# Altium schematic sheet SectionE_BreakOut.SchDoc(SectionE_BreakOut)
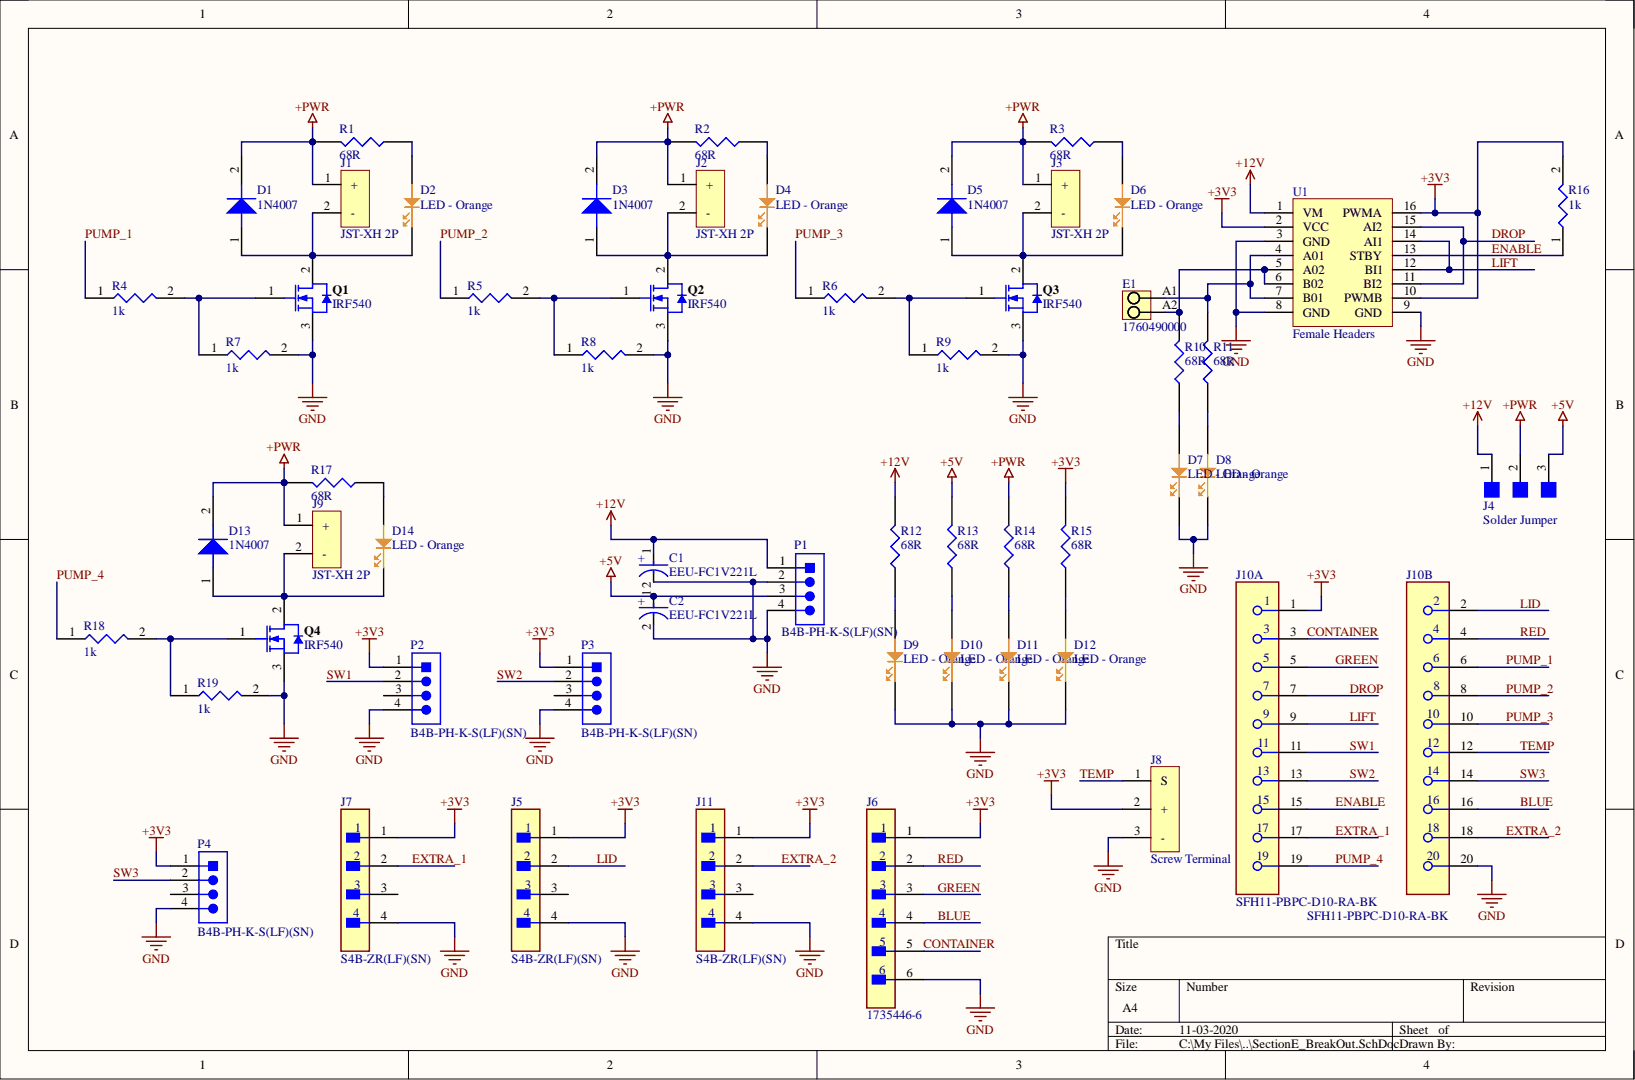
[diagram p0: SectionE_BreakOut.SchDoc]

## Parts
C1  EEU-FC1V221L  [FP-EEU-FC1V221L-MFG]
C2  EEU-FC1V221L  [FP-EEU-FC1V221L-MFG]
D1  1N4007  [M7 1N4007 SMA]
D2  LED - Orange  [LED0603-Orange]
D3  1N4007  [M7 1N4007 SMA]
D4  LED - Orange  [LED0603-Orange]
D5  1N4007  [M7 1N4007 SMA]
D6  LED - Orange  [LED0603-Orange]
D7  LED - Orange  [LED0603-Orange]
D8  LED - Orange  Color: Orange  [LED0603-Orange]
D9  LED - Orange  [LED0603-Orange]
D10  LED - Orange  Color: Orange  [LED0603-Orange]
D11  LED - Orange  Color: Orange  [LED0603-Orange]
D12  LED - Orange  Color: Orange  [LED0603-Orange]
D13  1N4007  [M7 1N4007 SMA]
D14  LED - Orange  [LED0603-Orange]
E1  1760490000  [PM_5_08_90_02]
J1  JST-XH 2P  [JST-XH 2P]
J2  JST-XH 2P  [JST-XH 2P]
J3  JST-XH 2P  [JST-XH 2P]
J4  Solder Jumper  [Solder Jumper]
J5  S4B-ZR(LF)(SN)  [FP-S4B-ZR_LF_SN-MFG]
J6  1735446-6  [FP-1735446-6-MFG]
J7  S4B-ZR(LF)(SN)  [FP-S4B-ZR_LF_SN-MFG]
J8  Screw Terminal  Termination: Screw  [Screw Terminal 3P 5.08MM]
J9  JST-XH 2P  [JST-XH 2P]
J10  SFH11-PBPC-D10-RA-BK  [FP-SFH11-PBPC-D10-RA-BK-MFG]  (parts A,B)
J11  S4B-ZR(LF)(SN)  [FP-S4B-ZR_LF_SN-MFG]
P1  B4B-PH-K-S(LF)(SN)  [JST-B4B-PH-K-S_V]
P2  B4B-PH-K-S(LF)(SN)  [JST-B4B-PH-K-S_V]
P3  B4B-PH-K-S(LF)(SN)  [JST-B4B-PH-K-S_V]
P4  B4B-PH-K-S(LF)(SN)  [JST-B4B-PH-K-S_V]
Q1  IRF540  [VISH-TO-220AB-3_A]
Q2  IRF540  [VISH-TO-220AB-3_A]
Q3  IRF540  [VISH-TO-220AB-3_A]
Q4  IRF540  [VISH-TO-220AB-3_A]
R1  68R  [68R 0603]
R2  68R  [68R 0603]
R3  68R  [68R 0603]
R4  1k  [R0603 1k]
R5  1k  [R0603 1k]
R6  1k  [R0603 1k]
R7  1k  [R0603 1k]
R8  1k  [R0603 1k]
R9  1k  [R0603 1k]
R10  68R  [68R 0603]
R11  68R  [68R 0603]
R12  68R  [68R 0603]
R13  68R  [68R 0603]
R14  68R  [68R 0603]
R15  68R  [68R 0603]
R16  1k  [R0603 1k]
R17  68R  [68R 0603]
R18  1k  [R0603 1k]
R19  1k  [R0603 1k]
U1  Female Headers  [TB6612FNG]

## Nets
+3V3: J5.1 J6.1 J7.1 J8.2 J10.1 J11.1 P2.1 P3.1 P4.1 R15.2 R16.2 U1.2(VCC) U1.10(PWMB) U1.16(PWMA)
+5V: C2.1 J4.3 P1.3 R13.2
+12V: C1.1 J4.1 P1.1 R12.2 U1.1(VM)
+PWR: D1.2 D3.2 D5.2 D13.2 J1.1 J2.1 J3.1 J4.2 J9.1 R1.2 R2.2 R3.2 R14.2 R17.2
GND: C1.2 C2.2 D7.1 D8.1 D9.1 D10.1 D11.1 D12.1 J5.4 J6.6 J7.4 J8.3 J10.20 J11.4 P1.2 P1.4 P2.4 P3.4 P4.4 Q1.3 Q2.3 Q3.3 Q4.3 R7.2 R8.2 R9.2 R19.2 U1.3(GND) U1.8(GND) U1.9(GND)
BLUE: J6.4 J10.16
CONTAINER: J6.5 J10.3
DROP: J10.7 U1.11(BI2) U1.15(AI2)
ENABLE: J10.15 R16.1 U1.13(STBY)
EXTRA_1: J7.2 J10.17
EXTRA_2: J10.18 J11.2
GREEN: J6.3 J10.5
LID: J5.2 J10.2
LIFT: J10.9 U1.12(BI1) U1.14(AI1)
PUMP_1: J10.6 R4.1
PUMP_2: J10.8 R5.1
PUMP_3: J10.10 R6.1
PUMP_4: J10.19 R18.1
RED: J6.2 J10.4
SW1: J10.11 P2.2
SW2: J10.13 P3.2
SW3: J10.14 P4.2
TEMP: J8.1 J10.12
NetD1_1: D1.1 D2.1 J1.2 Q1.2
NetD2_2: D2.2 R1.1
NetD3_1: D3.1 D4.1 J2.2 Q2.2
NetD4_2: D4.2 R2.1
NetD5_1: D5.1 D6.1 J3.2 Q3.2
NetD6_2: D6.2 R3.1
NetD7_2: D7.2 R10.1
NetD8_2: D8.2 R11.1
NetD9_2: D9.2 R12.1
NetD10_2: D10.2 R13.1
NetD11_2: D11.2 R14.1
NetD12_2: D12.2 R15.1
NetD13_1: D13.1 D14.1 J9.2 Q4.2
NetD14_2: D14.2 R17.1
NetE1_A1: E1.A1 R11.2 U1.4(A01) U1.7(B01)
NetE1_A2: E1.A2 R10.2 U1.5(A02) U1.6(B02)
NetQ1_1: Q1.1 R4.2 R7.1
NetQ2_1: Q2.1 R5.2 R8.1
NetQ3_1: Q3.1 R6.2 R9.1
NetQ4_1: Q4.1 R18.2 R19.1

Not connected: J5 3; J7 3; J11 3; P2 3; P3 3; P4 3

## Library data of the parts
C1 C2 = CMP-05427-000010-1: CAP ALUM 220UF 20% 35V RADIAL; Manufacturer: Panasonic Electronic Components; Automotive Grade: Grade 2; Dissipation Factor: 12%; Esr: 0.085Ω; Leakage Current: 77uA; Life: 2000Hours; Material: Aluminum; Ripple Current: 730mA; Automotive: Yes; Capacitance: 220uF; Category: Cap; Component Kind: Standard; ComponentLink2Description: Datasheet; ComponentLink2URL: https://industrial.panasonic.com/cdbs/www-data/pdf/RDF0000/ABA0000C1209.pdf; Device Class L1: Passive Components; Device Class L2: Capacitors; Device Class L3: Aluminum Electrolytic Capacitors; Digikey Description: CAP ALUM 220UF 20% 35V RADIAL; Height: 16.5mm; Lead Free: Yes; Library Name: Altium Content Vault; Manufacturer Part Number: EEU-FC1V221L; Mouser Description: Capacitor; Aluminum Electrolytic; Fc Radial Elec Cap 220uF 35V; Octopart: https://octopart.com/eeu-fc1v221l-panasonic-39478519; Package: 0; RoHS: TRUE; Temperature: -55°C to +105°C; Temperature Range High: +105°C; Temperature Range Low: -55°C; Tolerance: 20%; Value: 220uF; Voltage Rating: 35V
D1 D3 D5 D13 = 1N4007FLTR: 1000V 1A Rectifier; Manufacturer: Sangdest Microelectronics; Component Kind: Standard; Manufacturer Part Number: 1N4007FLTR; Pricing 1: 1=0.10000, 10=0.06600, 100=0.03120, 500=0.01848, 1000=0.01241 (USD); RoHS: No; Stock 1: 2517; Supplier 1: Digi-Key; Supplier Part Number 1: 1655-1N4007FLCT-ND; Library Name: SectionE_BreakOut.SchLib
D2 D4 D6-D12 D14 = LTST-C191KFKT: LED ORANGE CLEAR SMD; Manufacturer: Vishay Lite-On; Dominant Wavelength: 605nm; Forward Current: 30mA; Forward Voltage: 2V; Lens Color: Colorless; Lens Style: Diffused,Colorless,Rectangular; Luminous Intensity: 90mcd; Number of Elements: 1; Power Dissipation: 75mW; Reverse Voltage: 5V; Test Current: 20mA; Viewing Angle: 130°; Wavelength: 611nm; Case Code (Imperial): 0603; Case Code (Metric): 1608; Case/Package: 0603; Category: LEDs; Component Kind: Standard; ComponentLink1Description: https://datasheet.ciiva.com/2109/ltst-c191kfkt-2109968.pdf?src-supplier=Digi-Key; ComponentLink1URL: https://datasheet.ciiva.com/2109/ltst-c191kfkt-2109968.pdf?src-supplier=Digi-Key; ComponentLink2Description: https://datasheet.ciiva.com/12400/100-ltst-c191kfkt-39904-12400811.pdf?src-supplier=Mouser; ComponentLink2URL: https://datasheet.ciiva.com/12400/100-ltst-c191kfkt-39904-12400811.pdf?src-supplier=Mouser; ComponentLink3Description: https://datasheet.ciiva.com/11775/0900766b80dc02b0-11775613.pdf?src-supplier=RSComponents; ComponentLink3URL: https://datasheet.ciiva.com/11775/0900766b80dc02b0-11775613.pdf?src-supplier=RSComponents; ComponentLink4Description: https://datasheet.ciiva.com/15201/getdatasheetpartid-631075-15201391.pdf?src-supplier=Verical; ComponentLink4URL: https://datasheet.ciiva.com/15201/getdatasheetpartid-631075-15201391.pdf?src-supplier=Verical; ComponentLink5Description: https://datasheet.ciiva.com/2109/s-110-ltst-c191kfkt-2109969.pdf?src-supplier=Digi-Key; ComponentLink5URL: https://datasheet.ciiva.com/2109/s-110-ltst-c191kfkt-2109969.pdf?src-supplier=Digi-Key; Depth: 0.8mm; Height: 0.55mm; Illumination Color: Orange; Lead Free: Lead Free; Length: 1.6mm; Lens Transparency: Clear; Manufacturer Part Number: LTST-C191KFKT; Max Operating Temperature: 85°C; Min Operating Temperature: -55°C; Mount: Surface Mount; Number of LEDs: 1; Number of Pins: 2; Package Quantity: 1; Packaging: Tape and Reel; Power Rating: 75mW; Pricing 1: 1=0.24000, 10=0.17900, 100=0.08460, 500=0.05640, 1000=0.04418, 2500=0.04324 (USD); Radiation Hardening: No; RoHS: Yes; Stock 1: 92562; Supplier 1: Digi-Key; Supplier Part Number 1: 160-1445-1-ND; Width: 0.8mm; Library Name: SectionE_BreakOut.SchLib
  D2 D4 D6 D7 D9 D14: Color: Orange
E1 = CMP-1559-01627-1: OMNIMATE Signal - series PM; Manufacturer: Weidmueller; Contact_material: Cu-Leg; Contact_surface: tinned; Insulating_material: Wemid (PA); Mounting_onto_the_PCB: Solder connection; No_of_poles: 2; Pitch_inch: 0,2 inch; Pitch_mm: 5,08 mm; Rated_current_use_group_B_UL_1059: 15 A; Rated_voltage_for_surge_voltage_class_pollution_degree_II_2: 600 V; Rated_voltage_use_group_B_UL_1059: 300 V; Short_text_for_material: PM 5.08/02/90 3.5SN OR BX; Side_termination_characteristic: closed side; Solder_pin_length_mm: 3,5 mm; Solid_max_H05_07_V_U: 2,5 mm²; Solid_min_H05_07_V_U: 0,13 mm²; UL_94_flammability_rating: V-0; Wire_cross_section_AWG_max_UL_1059: AWG 14; Wire_cross_section_AWG_min_UL_1059: AWG 26; Colour: Orange; Component Kind: Standard; ComponentLink1Description: Manufacturer URL; ComponentLink1URL: http://www.weidmueller.com/; ComponentLink2Description: URL Link1; ComponentLink2URL: http://catalog.weidmueller.com/catalog/Start.do?localeId=en&ObjectID=1760490000; Library Name: Altium Content Vault; PackageReference: PM_5_08_90_02; Packaging: Box; PartNumber: 1760490000; Qty: 500 PCS; Revision: V1
J1-J3 J9 = B2B-XH-A(LF)(SN): JST-XH 2 POS 2.5mm Solder ST Thru-Hole Box; Manufacturer: JST; Connector Type: Male Pin; Contact Resistance: 20mR; Current Rating: 3A; Insulation Resistance: 1GR; Max Voltage Rating (AC): 250V; Number of Contacts: 2; Wire/Cable Gauge: 22AWG; Category: FFC / FPC; Color: Natural; Component Kind: Standard; ComponentLink1Description: https://datasheet.ciiva.com/26894/getdatasheetpartid-640357-26894434.pdf?src-supplier=Verical; ComponentLink1URL: https://datasheet.ciiva.com/26894/getdatasheetpartid-640357-26894434.pdf?src-supplier=Verical; ComponentLink2Description: https://datasheet.ciiva.com/11746/0900766b8082653e-11746979.pdf?src-supplier=RSComponents; ComponentLink2URL: https://datasheet.ciiva.com/11746/0900766b8082653e-11746979.pdf?src-supplier=RSComponents; ComponentLink3Description: https://datasheet.ciiva.com/26894/getdatasheetpartid-385291-26894760.pdf?src-supplier=Verical; ComponentLink3URL: https://datasheet.ciiva.com/26894/getdatasheetpartid-385291-26894760.pdf?src-supplier=Verical; ComponentLink4Description: https://datasheet.ciiva.com/8052/5448-8052024.pdf?src-supplier=Element14; ComponentLink4URL: https://datasheet.ciiva.com/8052/5448-8052024.pdf?src-supplier=Element14; ComponentLink5Description: https://datasheet.ciiva.com/20207/523653-20207167.pdf?src-supplier=Rapid+Electronics; ComponentLink5URL: https://datasheet.ciiva.com/20207/523653-20207167.pdf?src-supplier=Rapid+Electronics; Contact Material: Brass; Contact Plating: Tin,Copper,Lead; Depth: 5.75mm; Fastening Type: Detent; Housing Color: White; Housing Material: Polyamide; Lead Free: Lead Free; Lead Pitch: 2.5mm; Length: 7.4mm; Manufacturer Part Number: B2B-XH-A(LF)(SN); Max Current Rating: 3A; Max Operating Temperature: 85°C; Max Voltage Rating (DC): 250V; Min Operating Temperature: -25°C; Mount: Through Hole; Number of Positions: 2; Number of Rows: 1; Orientation: Straight; Packaging: Bulk; Pitch: 2.5mm; REACH SVHC: No SVHC; RoHS: Yes; Stock 1: 165899; Supplier 1: Element14; Supplier Part Number 1: 1516276; Termination: Solder; Voltage Rating: 250V; Voltage Rating (AC): 250V; Library Name: SectionE_BreakOut.SchLib
J5 J7 J11 = CMP-17439-000004-1: CONN HEADER R/A 4POS 1.5MM; Manufacturer: JST; Conn Gender: Male; Conn Orientation: Right Angle; Current Rating: 1A; Number Of Contacts: 4; Voltage Rating: 50V; Automotive: No; Category: Conn; Component Kind: Standard; ComponentLink2Description: Datasheet; ComponentLink2URL: http://www.jst-mfg.com/product/pdf/eng/eZH.pdf; Contact Material: Tin; Device Class L1: Connectors; Device Class L2: Headers and Wire Housings; Device Class L3: unset; Digikey Description: CONN HEADER R/A 4POS 1.5MM; Height: 3.7mm; Lead Free: Yes; Library Name: Altium Content Vault; Manufacturer Part Number: S4B-ZR(LF)(SN); Number Of Rows: 1; Octopart: https://octopart.com/s4b-zr%28lf%29%28sn%29-jst-248835; Package: S4B-ZR(LF)(SN); Pitch: 1.5mm; RoHS: TRUE; Temperature: -25°C to +85°C; Temperature Range High: +85°C; Temperature Range Low: -25°C
J6 = CMP-03369-000087-1: CONN HEADER VERT 6POS 2MM; Manufacturer: TE; Conn Gender: Male; Conn Orientation: Vertical; Current Rating: 3A; Number Of Contacts: 6; Voltage Rating: 100V; Automotive: No; Category: Conn; Component Kind: Standard; ComponentLink2Description: Datasheet; ComponentLink2URL: https://www.te.com/usa-en/product-1735446-6.html; Contact Material: Tin,Nickel; Device Class L1: Connectors; Device Class L2: Headers and Wire Housings; Device Class L3: unset; Digikey Description: CONN HEADER VERT 6POS 2MM; Height: 6.37mm; Lead Free: Yes; Library Name: Altium Content Vault; Manufacturer Part Number: 1735446-6; Mouser Description: Headers & Wire Housings 6 POS HDR VERT 2.0mm; Number Of Rows: 1; Octopart: https://octopart.com/1735446-6-te+connectivity-42205942; Package: 1735446-6; Pitch: 2mm; RoHS: TRUE; Temperature: -25°C to +85°C; Temperature Range High: +85°C; Temperature Range Low: -25°C
J8 = OSTTC032162: TERM BLK 3P SIDE ENT 5.08MM PCB; Manufacturer: On-Shore Technology; Current: 15A; Number of Contacts: 3; Number of Levels: 1; Pitch: 0.2inch; Plating: Steel; Voltage: 300V; Wire Gauge (Max): 22AWG; Wire Gauge (Min): 14AWG; Category: Terminal Blocks; Color: Blue; Component Kind: Standard; ComponentLink1Description: https://datasheet.ciiva.com/823/osttcxx2162-823568.pdf?src-supplier=Digi-Key; ComponentLink1URL: https://datasheet.ciiva.com/823/osttcxx2162-823568.pdf?src-supplier=Digi-Key; ComponentLink2Description: https://datasheet.ciiva.com/19458/2120655-19458972.pdf?src-supplier=Jameco; ComponentLink2URL: https://datasheet.ciiva.com/19458/2120655-19458972.pdf?src-supplier=Jameco; Contact Material: Brass; Contact Plating: Tin; Flammability Rating: UL94 V-0; Height: 10mm; Housing Color: Blue; Housing Material: Thermoplastic; Lead Free: Lead Free; Length: 15.2mm; Manufacturer Part Number: OSTTC032162; Max Current Rating: 15A; Max Operating Temperature: 105°C; Min Operating Temperature: -30°C; Mount: Through Hole; Number of Positions: 3; Number of Positions Per Level: 3; Number of Rows: 1; Packaging: Bulk; Pricing 1: 1=0.85000, 10=0.53000, 25=0.45400, 50=0.40800, 100=0.39950, 250=0.34000, 500=0.32300, 1000=0.28900, 5000=0.22610 (USD); RoHS: Yes; Stock 1: 3645; Supplier 1: Digi-Key; Supplier Part Number 1: ED2610-ND; Width: 7.6mm; Library Name: SectionE_BreakOut.SchLib
J10 = CMP-25680-000003-1: CONN HDR 20POS 0.1 GOLD PCB R/A; Manufacturer: Sullins; Conn Gender: Female; Conn Orientation: Right Angle; Current Rating: 3A; Is Female: yes; Number Of Contacts: 20; Pitch: 2.54mm; Automotive: No; Category: Conn; Component Kind: Standard; ComponentLink2Description: Datasheet; ComponentLink2URL: https://s3.amazonaws.com/catalogspreads-pdf/PAGE123%20.100%20SFH11%20SERIES%20FEMALE%20HDR%20ST%20RA.pdf; Contact Material: Gold,Nickel; Device Class L1: Connectors; Device Class L2: Headers and Wire Housings; Device Class L3: unset; Digikey Description: CONN HDR 20POS 0.1 GOLD PCB R/A; Footprint Url: https://drawings-pdf.s3.amazonaws.com/11161.pdf; Height: 7.2mm; Lead Free: Yes; Library Name: Altium Content Vault; Manufacturer Part Number: SFH11-PBPC-D10-RA-BK; Number Of Rows: 2; Octopart: https://octopart.com/sfh11-pbpc-d10-ra-bk-sullins-12270496; Package: SFH11-PBPC-D10-RA-BK; RoHS: TRUE; Temperature: -40°C to +105°C; Temperature Range High: +105°C; Temperature Range Low: -40°C
P1-P4 = CMP-2000-05165-1: Male Header, Pitch 2 mm, 1 x 4 Position, Height 6 mm, Tail Length 3.4 mm, -25 to 85 degC, RoHS, Bulk; Manufacturer: JST; No of Positions: 4; Pitch: 2mm; Style/Orientation: StraightHeader/Plug; Component Kind: Standard; Datasheet URL: http://datasheet.octopart.com/B4B-PH-K-S%28LF%29%28SN%29-JST-datasheet-10558886.pdf; Datasheet Version: Apr-09; Library Name: Altium Content Vault; Manufacturer URL: http://www.jst.com/home2.html; Termination: Crimp; Type: ShroudedHeader
Q1-Q4 = CMP-2000-06461-1: Power MOSFET, 100 VDS, -55 to 175 degC, 3-Pin TO-220AB, RoHS, Tube; Manufacturer: Vishay; Brand: VishaySemiconductors; Channel Mode: Enhancement; Configuration: Single; Fall Time: 43ns; Id - Continuous Drain Current: 28A; Number of Channels: 1Channel; Pd - Power Dissipation: 150W; Rds On - Drain-Source Resistance: 77mOhms; Rise Time: 44ns; Transistor Polarity: N-Channel; Transistor Type: 1N-Channel; Typical Turn-Off Delay Time: 53ns; Typical Turn-On Delay Time: 11ns; Unit Weight: 0.211644oz; Vds - Drain-Source Breakdown Voltage: 100V; Vgs - Gate-Source Voltage: 20V; &lt;PCN&gt;: http://www.mouser.com/PCN/Vishay_SIL_0182015.pdf; Component Kind: Standard; Datasheet URL: http://www.vishay.com/docs/91021/91021.pdf; Datasheet Version: Rev. B, 03/2011; Factory Pack Quantity: 50; Height: 9.01mm; Length: 10.51mm; Library Name: Altium Content Vault; Manufacturer URL: http://www.vishay.com/; Maximum Operating Temperature: +175C; Minimum Operating Temperature: -55C; Mounting Style: ThroughHole; Mounting Technology: ThroughHole; Package / Case: TO-220-3; Package Description: 3-Pin Flange Mount, Body 10.275 x 4.45 mm, Pitch 2.54 mm; Package Reference: TO-220AB-3; Package URL: http://www.vishay.com/docs/71195/to220ab.pdf; Packaging: Tube; Product Category: MOSFET; RoHS: NoRoHSVersionAvailable; Technology: Si; Width: 4.7mm
R1-R3 R10-R15 R17 = CRGP0603F68R: CRGP 0603 68R 1%; Manufacturer: TE Connectivity; Max Power Dissipation: 250mW; Temperature Coefficient: 200ppm/°C; Case Code (Imperial): 0603; Case Code (Metric): 1608; Case/Package: 0603; Category: Chip SMD Resistors; Component Kind: Standard; ComponentLink1Description: https://www.te.com/commerce/DocumentDelivery/DDEController?Action=srchrtrv&amp;DocNm=9-1773463-9&amp;DocType=DS&amp;DocLang=English&src-supplier=Digi-Key; ComponentLink1URL: https://www.te.com/commerce/DocumentDelivery/DDEController?Action=srchrtrv&amp;DocNm=9-1773463-9&amp;DocType=DS&amp;DocLang=English&src-supplier=Digi-Key; Manufacturer Part Number: CRGP0603F68R; Max Operating Temperature: 155°C; Min Operating Temperature: -55°C; Number of Terminations: 2; Packaging: Tape and Reel; Pricing 1: 1=0.14000, 10=0.11400, 100=0.04470, 1000=0.01870, 2500=0.01714 (USD); Resistance: 68R; Resistor Type: Thick Film; RoHS: No; Stock 1: 49308; Supplier 1: Digi-Key; Supplier Part Number 1: A130402CT-ND; Tolerance: 1%; Library Name: SectionE_BreakOut.SchLib
R4-R9 R16 R18 R19 = CRGCQ0603F1K0: 0603 1k Ohm 1% 1/10W; Manufacturer: TE Connectivity; Max Power Dissipation: 100mW; Resistance: 1kR; Temperature Coefficient: 100ppm/°C; Case Code (Imperial): 0603; Case Code (Metric): 1608; Case/Package: 0603; Category: Chip SMD Resistors; Component Kind: Standard; ComponentLink1Description: https://www.te.com/commerce/DocumentDelivery/DDEController?Action=srchrtrv&DocNm=1773204-3&DocType=DS&DocLang=English&src-supplier=Digi-Key; ComponentLink1URL: https://www.te.com/commerce/DocumentDelivery/DDEController?Action=srchrtrv&DocNm=1773204-3&DocType=DS&DocLang=English&src-supplier=Digi-Key; ComponentLink2Description: https://4donline.ihs.com/images/VipMasterIC/IC/TYEL/TYEL-S-A0004929575/TYEL-S-A0004929575-1.pdf?hkey=52A5661711E402568146F3353EA87419&src-supplier=Farnell; ComponentLink2URL: https://4donline.ihs.com/images/VipMasterIC/IC/TYEL/TYEL-S-A0004929575/TYEL-S-A0004929575-1.pdf?hkey=52A5661711E402568146F3353EA87419&src-supplier=Farnell; ComponentLink3Description: https://www.te.com/commerce/DocumentDelivery/DDEController?Action=srchrtrv&amp;DocNm=1773204-3&amp;DocType=DS&amp;DocLang=English&src-supplier=Digi-Key; ComponentLink3URL: https://www.te.com/commerce/DocumentDelivery/DDEController?Action=srchrtrv&amp;DocNm=1773204-3&amp;DocType=DS&amp;DocLang=English&src-supplier=Digi-Key; ComponentLink4Description: https://4donline.ihs.com/images/VipMasterIC/IC/TYEL/TYEL-S-A0004929575/TYEL-S-A0004929575-1.pdf?hkey=52A5661711E402568146F3353EA87419&src-supplier=Element14; ComponentLink4URL: https://4donline.ihs.com/images/VipMasterIC/IC/TYEL/TYEL-S-A0004929575/TYEL-S-A0004929575-1.pdf?hkey=52A5661711E402568146F3353EA87419&src-supplier=Element14; ComponentLink5Description: https://4donline.ihs.com/images/VipMasterIC/IC/TYEL/TYEL-S-A0004929575/TYEL-S-A0004929575-1.pdf?hkey=52A5661711E402568146F3353EA87419&src-supplier=Newark; ComponentLink5URL: https://4donline.ihs.com/images/VipMasterIC/IC/TYEL/TYEL-S-A0004929575/TYEL-S-A0004929575-1.pdf?hkey=52A5661711E402568146F3353EA87419&src-supplier=Newark; Features: Moisture Resistant,Automotive AEC-Q200; Manufacturer Part Number: CRGCQ0603F1K0; Max Operating Temperature: 155°C; Min Operating Temperature: -55°C; Number of Terminations: 2; Packaging: Cut Tape; Pricing 1: 1=0.10000, 10=0.02700, 100=0.01090, 1000=0.00490, 2500=0.00426 (USD); Resistor Type: Thick Film; RoHS: No; Stock 1: 37973; Supplier 1: Digi-Key; Supplier Part Number 1: A129688CT-ND; Tolerance: 1%; Library Name: SectionE_BreakOut.SchLib
U1 = TB6612FNG Female: Motor Driver; Component Kind: Standard; Library Name: SectionE_BreakOut.SchLib
J4 = Solder Jumper: Component Kind: Standard; Library Name: SectionE_BreakOut.SchLib
